# Altium schematic sheet 1_MICROCONTROLADOR.SchDoc
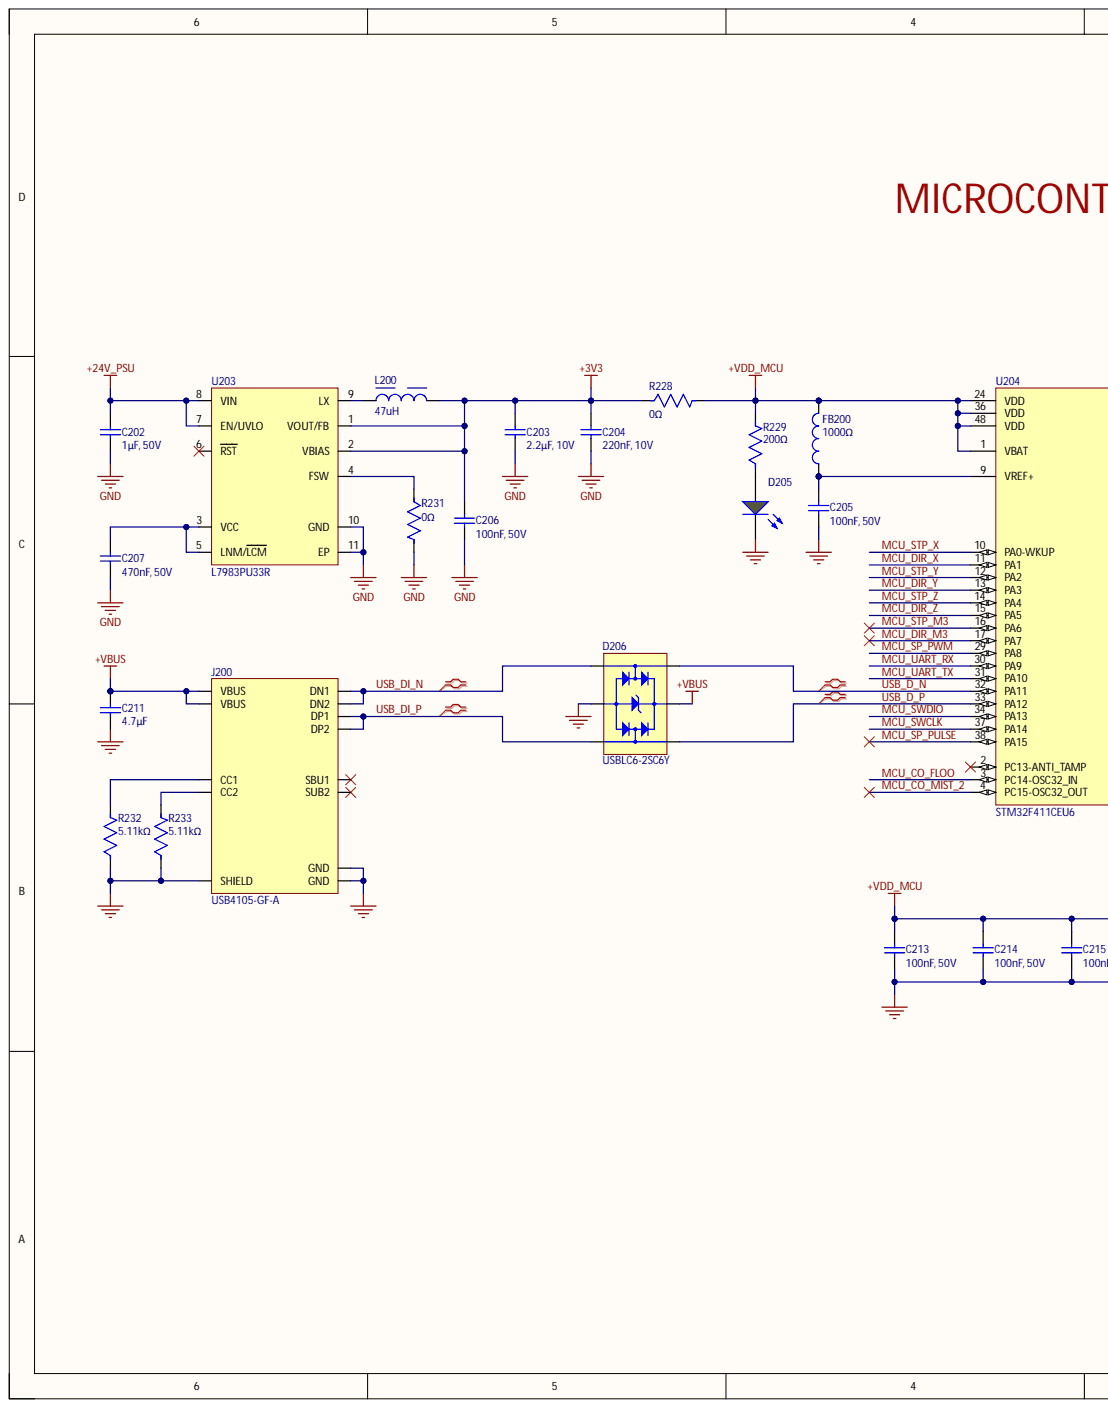
[diagram p0: 1_MICROCONTROLADOR.SchDoc, left]
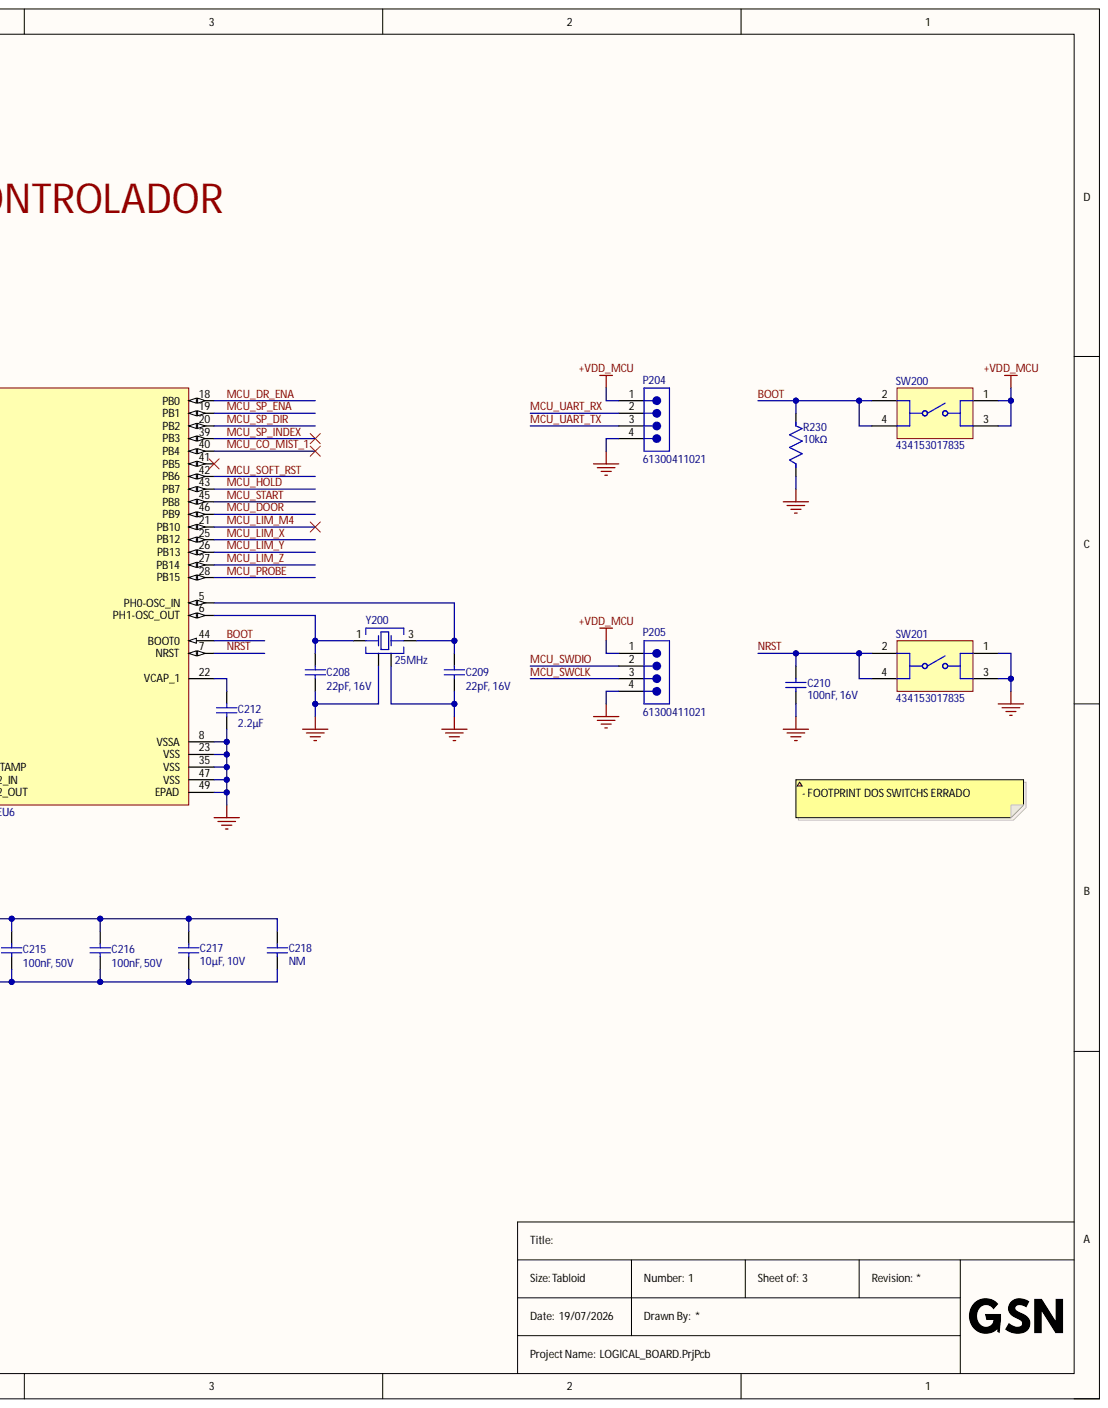
[diagram p1: 1_MICROCONTROLADOR.SchDoc, right]

## Parts
C202  1μF, 50V  Rated Voltage (DC): 50V  [WCAP-CSGP_0805_L2W1.25H1.25]
C203  2.2μF, 10V  [WCAP-CSGP_0603_L1.6W0.8H0.8_Wave]
C204  220nF, 10V  Rated Voltage (DC): 10V  [WCAP-CSGP_0603_L1.6W0.8H0.8_Wave]
C205  100nF, 50V  [WCAP-CSGP_0603_L1.6W0.8H0.8_Wave]
C206  100nF, 50V  Rated Voltage (DC): 50V  [WCAP-CSGP_0603_L1.6W0.8H0.8_Wave]
C207  470nF, 50V  [WCAP-CSGP_0805_L2W1.25H1.25]
C208  22pF, 16V  Rated Voltage (DC): 16V  [WCAP-CSGP_0603_L1.6W0.8H0.8_Wave]
C209  22pF, 16V  Rated Voltage (DC): 16V  [WCAP-CSGP_0603_L1.6W0.8H0.8_Wave]
C210  100nF, 16V  [WCAP-CSGP_0603_L1.6W0.8H0.8_Wave]
C211  4.7μF  [WCAP-CSGP_0805_L2W1.25H1.25]
C212  2.2μF  [WCAP-CSGP_0603_L1.6W0.8H0.8_Wave]
C213  100nF, 50V  [WCAP-CSGP_0603_L1.6W0.8H0.8_Wave]
C214  100nF, 50V  Rated Voltage (DC): 50V  [WCAP-CSGP_0603_L1.6W0.8H0.8_Wave]
C215  100nF, 50V  Rated Voltage (DC): 50V  [WCAP-CSGP_0603_L1.6W0.8H0.8_Wave]
C216  100nF, 50V  Rated Voltage (DC): 50V  [WCAP-CSGP_0603_L1.6W0.8H0.8_Wave]
C217  10μF, 10V  Rated Voltage (DC): 10V  [WCAP-CSGP_0805_L2W1.25H1.25]
C218  NM  [WCAP-CSGP_0805_L2W1.25H1.25]
D205  Bright Green, 2V, 140deg  [WL-SMCW_0603_150060xx75000]  (value not drawn)
D206  USBLC6-2SC6Y  [SOT-23-6]
FB200  1000Ω  [WE-CBF_0603_L1.6W0.8H0.8_HS_WB]
J200  USB4105-GF-A  [USB4105-GF-A]
L200  47uH  [WE-MAIA_3015]
P204  61300411021  [61300411021]
P205  61300411021  [61300411021]
R228  0Ω  [0805-WAVE]
R229  200Ω  [0603-WAVE]
R230  10kΩ  [0603-WAVE]
R231  0Ω  [0603-WAVE]
R232  5.11kΩ  [0603-WAVE]
R233  5.11kΩ  [0603-WAVE]
SW200  434153017835  [434153017835]
SW201  434153017835  [434153017835]
U203  L7983PU33R  [10-WFDFN-EP]
U204  STM32F411CEU6  [UFQFN-48-7X7_EXPOSED_PAD]
Y200  25MHz  [WE-XTAL_CFPX-180]

## Nets
+3V3: C203.2 C204.2 C206.2 L200.2 R228.1 U203.1(VOUT/FB) U203.2(VBIAS)
+24V_PSU: C202.2 U203.7(EN/UVLO) U203.8(VIN)
+VBUS: C211.2 D206.5 J200.A4_B9(VBUS) J200.B4_A9(VBUS)
+VDD_MCU: C213.2 C214.2 C215.2 C216.2 C217.2 C218.2 FB200.2 P204.1 P205.1 R228.2 R229.2 SW200.1 SW200.3 U204.1(VBAT) U204.24(VDD) U204.36(VDD) U204.48(VDD)
GND: C202.1 C203.1 C204.1 C205.1 C206.1 C207.1 C208.1 C209.1 C210.1 C211.1 C212.1 C213.1 C214.1 C215.1 C216.1 C217.1 C218.1 D205.1 D206.2 J200.A1_B12(GND) J200.B1_A12(GND) J200.SH(SHIELD) P204.4 P205.4 R230.1 R231.2 R232.1 R233.1 SW201.1 SW201.3 U203.10(GND) U203.11(EP) U204.8(VSSA) U204.23(VSS) U204.35(VSS) U204.47(VSS) U204.49(EPAD) Y200.2 Y200.4
BOOT: R230.2 SW200.2 SW200.4 U204.44(BOOT0)
MCU_CO_FLOO: U204.3(PC14-OSC32_IN)
MCU_CO_MIST_1: U204.40(PB4)
MCU_CO_MIST_2: U204.4(PC15-OSC32_OUT)
MCU_DIR_M3: U204.17(PA7)
MCU_DIR_X: U204.11(PA1)
MCU_DIR_Y: U204.13(PA3)
MCU_DIR_Z: U204.15(PA5)
MCU_DOOR: U204.46(PB9)
MCU_DR_ENA: U204.18(PB0)
MCU_HOLD: U204.43(PB7)
MCU_LIM_M4: U204.21(PB10)
MCU_LIM_X: U204.25(PB12)
MCU_LIM_Y: U204.26(PB13)
MCU_LIM_Z: U204.27(PB14)
MCU_PROBE: U204.28(PB15)
MCU_SOFT_RST: U204.42(PB6)
MCU_SP_DIR: U204.20(PB2)
MCU_SP_ENA: U204.19(PB1)
MCU_SP_INDEX: U204.39(PB3)
MCU_SP_PULSE: U204.38(PA15)
MCU_SP_PWM: U204.29(PA8)
MCU_START: U204.45(PB8)
MCU_STP_M3: U204.16(PA6)
MCU_STP_X: U204.10(PA0-WKUP)
MCU_STP_Y: U204.12(PA2)
MCU_STP_Z: U204.14(PA4)
MCU_SWCLK: P205.3 U204.37(PA14)
MCU_SWDIO: P205.2 U204.34(PA13)
MCU_UART_RX: P204.2 U204.30(PA9)
MCU_UART_TX: P204.3 U204.31(PA10)
NRST: C210.2 SW201.2 SW201.4 U204.7(NRST)
USB_D_N: D206.6 U204.32(PA11)
USB_D_P: D206.4 U204.33(PA12)
USB_DI_N: D206.1 J200.A7(DN1) J200.B7(DN2)
USB_DI_P: D206.3 J200.A6(DP1) J200.B6(DP2)
NetC205_2: C205.2 FB200.1 U204.9(VREF+)
NetC207_2: C207.2 U203.3(VCC) U203.5(LNM/LCM)
NetC208_2: C208.2 U204.6(PH1-OSC_OUT) Y200.1
NetC209_2: C209.2 U204.5(PH0-OSC_IN) Y200.3
NetC212_2: C212.2 U204.22(VCAP_1)
NetD205_2: D205.2 R229.1
NetJ200_A5: J200.A5(CC1) R232.2
NetJ200_B5: J200.B5(CC2) R233.2
NetL200_1: L200.1 U203.9(LX)
NetR231_1: R231.1 U203.4(FSW)

Not connected: J200 A8(SBU1) B8(SUB2); U203 6(RST); U204 2(PC13-ANTI_TAMP) 41(PB5)

## Library data of the parts
C202 = 885012207103R: WCAP-CSGP Multilayer Ceramic Chip Capacitor, General Purpose, size 0805, 1μF, 50V; Manufacturer: Wurth Elektronik; Capacitance: 1uF; Capacitance Tolerance: +/-10%; Case/Size Code: 0805; Ceramic Type: X7R; Database Table Name: Capacitors; Dissipation Factor: 10%; Insulation Resistance: 0.1Gohm; Technical Reference: X7R0805105K050DFCT20000; Category: Multilayer Ceramic Chip Capacitors; Component Kind: Standard; ComponentLink1Description: Website Link; ComponentLink1URL: https://www.we-online.com/redexpert/article/885012207103R?ad; ComponentLink2Description: Datasheet Link; ComponentLink2URL: https://www.we-online.com/redexpert/spec/885012207103R?ad; Height: 1.25mm; Length: 2mm; Library Name: WE - Passive Components.DbLib; Manufacturer Part Number: 885012207103R; Match Code: WCAP-CSGP; Mount: Surface Mount; Operating Temperature Max: 125degC; Operating Temperature Min: -55degC; Packaging: Tape and Reel; Simulation: 0805_885012207103R_1uF; Width: 1.25mm
C203 = 885012206027: WCAP-CSGP Multilayer Ceramic Chip Capacitor, General Purpose, size 0603, 2.2μF, 10V; Manufacturer: Wurth Elektronik; Capacitance: 2.2uF; Capacitance Tolerance: +/-10%; Case/Size Code: 0603; Ceramic Type: X7R; Database Table Name: Capacitors; Dissipation Factor: 10%; Insulation Resistance: 0.02Gohm; Technical Reference: X7R0603225K010DFCT10000; Category: Multilayer Ceramic Chip Capacitors; Component Kind: Standard; ComponentLink1Description: Website Link; ComponentLink1URL: https://www.we-online.com/redexpert/article/885012206027?ad; ComponentLink2Description: Datasheet Link; ComponentLink2URL: https://www.we-online.com/redexpert/spec/885012206027?ad; Height: 0.8mm; Length: 1.6mm; Library Name: WE - Passive Components.DbLib; Manufacturer Part Number: 885012206027; Match Code: WCAP-CSGP; Mount: Surface Mount; Operating Temperature Max: 125degC; Operating Temperature Min: -55degC; Packaging: Tape and Reel; Rated Voltage (DC): 10V; Simulation: 0603_885012206027_2.2uF; Width: 0.8mm
C204 = 885012206022: WCAP-CSGP Multilayer Ceramic Chip Capacitor, General Purpose, size 0603, 220nF, 10V; Manufacturer: Wurth Elektronik; Capacitance Tolerance: +/-10%; Case/Size Code: 0603; Ceramic Type: X7R; Database Table Name: Capacitors; Dissipation Factor: 5%; Insulation Resistance: 2.3Gohm; Technical Reference: X7R0603224K010DFCT10000; Capacitance: 220nF; Category: Multilayer Ceramic Chip Capacitors; Component Kind: Standard; ComponentLink1Description: Website Link; ComponentLink1URL: https://www.we-online.com/redexpert/article/885012206022?ad; ComponentLink2Description: Datasheet Link; ComponentLink2URL: https://www.we-online.com/redexpert/spec/885012206022?ad; Height: 0.8mm; Length: 1.6mm; Library Name: WE - Passive Components.DbLib; Manufacturer Part Number: 885012206022; Match Code: WCAP-CSGP; Mount: Surface Mount; Operating Temperature Max: 125degC; Operating Temperature Min: -55degC; Packaging: Tape and Reel; Simulation: 0603_885012206022_220nF; Width: 0.8mm
C205 C206 C213-C216 = 885012206095: WCAP-CSGP Multilayer Ceramic Chip Capacitor, General Purpose, size 0603, 100nF, 50V; Manufacturer: Wurth Elektronik; Capacitance Tolerance: +/-10%; Case/Size Code: 0603; Ceramic Type: X7R; Database Table Name: Capacitors; Dissipation Factor: 3%; Insulation Resistance: 5Gohm; Technical Reference: X7R0603104K050DFCT10000; Capacitance: 100nF; Category: Multilayer Ceramic Chip Capacitors; Component Kind: Standard; ComponentLink1Description: Website Link; ComponentLink1URL: https://www.we-online.com/redexpert/article/885012206095?ad; ComponentLink2Description: Datasheet Link; ComponentLink2URL: https://www.we-online.com/redexpert/spec/885012206095?ad; Height: 0.8mm; Length: 1.6mm; Library Name: WE - Passive Components.DbLib; Manufacturer Part Number: 885012206095; Match Code: WCAP-CSGP; Mount: Surface Mount; Operating Temperature Max: 125degC; Operating Temperature Min: -55degC; Packaging: Tape and Reel; Simulation: 0603_885012206095_100nF; Width: 0.8mm
  C205 C213: Rated Voltage (DC): 50V
C207 = 885012207102: WCAP-CSGP Multilayer Ceramic Chip Capacitor, General Purpose, size 0805, 470nF, 50V; Manufacturer: Wurth Elektronik; Capacitance Tolerance: +/-10%; Case/Size Code: 0805; Ceramic Type: X7R; Database Table Name: Capacitors; Dissipation Factor: 3%; Insulation Resistance: 1.1Gohm; Technical Reference: X7R0805474K050DFCT10000; Capacitance: 470nF; Category: Multilayer Ceramic Chip Capacitors; Component Kind: Standard; ComponentLink1Description: Website Link; ComponentLink1URL: https://www.we-online.com/redexpert/article/885012207102?ad; ComponentLink2Description: Datasheet Link; ComponentLink2URL: https://www.we-online.com/redexpert/spec/885012207102?ad; Height: 1.25mm; Length: 2mm; Library Name: WE - Passive Components.DbLib; Manufacturer Part Number: 885012207102; Match Code: WCAP-CSGP; Mount: Surface Mount; Operating Temperature Max: 125degC; Operating Temperature Min: -55degC; Packaging: Tape and Reel; Rated Voltage (DC): 50V; Simulation: 0805_885012207102_470nF; Width: 1.25mm
C208 C209 = 885012006019: WCAP-CSGP Multilayer Ceramic Chip Capacitor, General Purpose, size 0603, 22pF, 16V; Manufacturer: Wurth Elektronik; Capacitance Tolerance: +/-5%; Case/Size Code: 0603; Ceramic Type: NP0; Database Table Name: Capacitors; Insulation Resistance: 10Gohm; Q Factor: 840; Technical Reference: NP00603220J016DFCT10000; Capacitance: 22pF; Category: Multilayer Ceramic Chip Capacitors; Component Kind: Standard; ComponentLink1Description: Website Link; ComponentLink1URL: https://www.we-online.com/redexpert/article/885012006019?ad; ComponentLink2Description: Datasheet Link; ComponentLink2URL: https://www.we-online.com/redexpert/spec/885012006019?ad; Height: 0.8mm; Length: 1.6mm; Library Name: WE - Passive Components.DbLib; Manufacturer Part Number: 885012006019; Match Code: WCAP-CSGP; Mount: Surface Mount; Operating Temperature Max: 125degC; Operating Temperature Min: -55degC; Packaging: Tape and Reel; Simulation: 0603_885012006019_22pF; Width: 0.8mm
C210 = 885012206046: WCAP-CSGP Multilayer Ceramic Chip Capacitor, General Purpose, size 0603, 100nF, 16V; Manufacturer: Wurth Elektronik; Capacitance Tolerance: +/-10%; Case/Size Code: 0603; Ceramic Type: X7R; Database Table Name: Capacitors; Dissipation Factor: 3.5%; Insulation Resistance: 5Gohm; Technical Reference: X7R0603104K016DFCT10000; Capacitance: 100nF; Category: Multilayer Ceramic Chip Capacitors; Component Kind: Standard; ComponentLink1Description: Website Link; ComponentLink1URL: https://www.we-online.com/redexpert/article/885012206046?ad; ComponentLink2Description: Datasheet Link; ComponentLink2URL: https://www.we-online.com/redexpert/spec/885012206046?ad; Height: 0.8mm; Length: 1.6mm; Library Name: WE - Passive Components.DbLib; Manufacturer Part Number: 885012206046; Match Code: WCAP-CSGP; Mount: Surface Mount; Operating Temperature Max: 125degC; Operating Temperature Min: -55degC; Packaging: Tape and Reel; Rated Voltage (DC): 16V; Simulation: 0603_885012206046_100nF; Width: 0.8mm
C211 = 885012207053: WCAP-CSGP Multilayer Ceramic Chip Capacitor, General Purpose, size 0805, 4.7μF, 16V; Manufacturer: Wurth Elektronik; Capacitance: 4.7uF; Capacitance Tolerance: +/-10%; Case/Size Code: 0805; Ceramic Type: X7R; Database Table Name: Capacitors; Dissipation Factor: 10%; Insulation Resistance: 0.02Gohm; Technical Reference: X7R0805475K016DFCT10000; Category: Multilayer Ceramic Chip Capacitors; Component Kind: Standard; ComponentLink1Description: Website Link; ComponentLink1URL: https://www.we-online.com/redexpert/article/885012207053?ad; ComponentLink2Description: Datasheet Link; ComponentLink2URL: https://www.we-online.com/redexpert/spec/885012207053?ad; Height: 1.25mm; Length: 2mm; Library Name: WE - Passive Components.DbLib; Manufacturer Part Number: 885012207053; Match Code: WCAP-CSGP; Mount: Surface Mount; Operating Temperature Max: 125degC; Operating Temperature Min: -55degC; Packaging: Tape and Reel; Rated Voltage (DC): 16V; Simulation: 0805_885012207053_4.7uF; Width: 1.25mm
C212 = 885012106004: WCAP-CSGP Multilayer Ceramic Chip Capacitor, General Purpose, size 0603, 2.2μF, 6.3V; Manufacturer: Wurth Elektronik; Capacitance: 2.2uF; Capacitance Tolerance: +/-20%; Case/Size Code: 0603; Ceramic Type: X5R; Database Table Name: Capacitors; Dissipation Factor: 10%; Insulation Resistance: 0.05Gohm; Technical Reference: X5R0603225M6R3DFCT10000; Category: Multilayer Ceramic Chip Capacitors; Component Kind: Standard; ComponentLink1Description: Website Link; ComponentLink1URL: https://www.we-online.com/redexpert/article/885012106004?ad; ComponentLink2Description: Datasheet Link; ComponentLink2URL: https://www.we-online.com/redexpert/spec/885012106004?ad; Height: 0.8mm; Length: 1.6mm; Library Name: WE - Passive Components.DbLib; Manufacturer Part Number: 885012106004; Match Code: WCAP-CSGP; Mount: Surface Mount; Operating Temperature Max: 85degC; Operating Temperature Min: -55degC; Packaging: Tape and Reel; Rated Voltage (DC): 6.3V; Simulation: 0603_885012106004_2.2uF; Width: 0.8mm
C217 C218 = 885012207026: WCAP-CSGP Multilayer Ceramic Chip Capacitor, General Purpose, size 0805, 10μF, 10V; Manufacturer: Wurth Elektronik; Capacitance: 10uF; Capacitance Tolerance: +/-10%; Case/Size Code: 0805; Ceramic Type: X7R; Database Table Name: Capacitors; Dissipation Factor: 10%; Insulation Resistance: 0.01Gohm; Technical Reference: X7R0805106K010DFCT10000; Category: Multilayer Ceramic Chip Capacitors; Component Kind: Standard; ComponentLink1Description: Website Link; ComponentLink1URL: https://www.we-online.com/redexpert/article/885012207026?ad; ComponentLink2Description: Datasheet Link; ComponentLink2URL: https://www.we-online.com/redexpert/spec/885012207026?ad; Height: 1.25mm; Length: 2mm; Library Name: WE - Passive Components.DbLib; Manufacturer Part Number: 885012207026; Match Code: WCAP-CSGP; Mount: Surface Mount; Operating Temperature Max: 125degC; Operating Temperature Min: -55degC; Packaging: Tape and Reel; Simulation: 0805_885012207026_10uF; Width: 1.25mm
  C218: Rated Voltage (DC): 10V
D205 = 150060VS75000: WL-SMCW Mono-color Chip LED Waterclear, SMT, size 0603, Bright Green, 2V, 140°; Manufacturer: Wurth Elektronik; Case/Size Code: 0603; Chip Technology: AlInGaP; Database Table Name: LEDs; Dominant Wavelength: 570nm; Luminous Intensity: 40mcd; Peak Wavelength: 572nm; Category: Signal LEDs; Component Kind: Standard; ComponentLink1Description: Website Link; ComponentLink1URL: https://www.we-online.com/redexpert/article/150060VS75000?ad; ComponentLink2Description: Datasheet Link; ComponentLink2URL: https://www.we-online.com/redexpert/spec/150060VS75000?ad; Emitting Color: Bright Green; Forward Voltage: 2V; Height: 0.7mm; Length: 1.6mm; Library Name: WE - Optoelectronic Components.DbLib; Manufacturer Part Number: 150060VS75000; Match Code: WL-SMCW; Mount: Surface Mount; Operating Temperature Max: 85degC; Operating Temperature Min: -40degC; Packaging: Tape and Reel; Simulation: 0603_150060VS75000; Viewing Angle: 140deg; Width: 0.8mm
D206 = USBLC6-2SC6Y: TVS DIODE 5.25VWM 17VC SOT23-6; Manufacturer: STMicroelectronics; Breakdown Voltage: 6V; Clamping Voltage: 17V; Direction: Unidirectional; Element Configuration: Dual; ESD Protection: Yes; ESR: 330Ω; Forward Voltage: 860mV; Leakage Current: 150nA; Max Junction Temperature (Tj): 150°C; Number of Bidirectional Channels: 2; Number of Elements: 1; Operating Supply Voltage: 1.1V; Peak Pulse Current: 5A; Reverse Standoff Voltage: 5.25V; Test Current: 1mA; Value: 2.5pF; Case/Package: SOT-23-6; Category: TVS Diodes; China RoHS: Compliant; Component Kind: Standard; ComponentLink1Description: https://datasheet.octopart.com:443/USBLC6-2SC6Y-STMicroelectronics-datasheet-12533815.pdf?src-supplier=ODG+(Origin+Data+Global); ComponentLink1URL: https://datasheet.octopart.com:443/USBLC6-2SC6Y-STMicroelectronics-datasheet-12533815.pdf?src-supplier=ODG+(Origin+Data+Global); ComponentLink2Description: https://datasheet.octopart.com:443/USBLC6-2SC6Y-STMicroelectronics-datasheet-13733956.pdf?src-supplier=STMicroelectronics; ComponentLink2URL: https://datasheet.octopart.com:443/USBLC6-2SC6Y-STMicroelectronics-datasheet-13733956.pdf?src-supplier=STMicroelectronics; ComponentLink3Description: https://datasheet.octopart.com:443/USBLC6-2SC6Y-STMicroelectronics-datasheet-10412581.pdf?src-supplier=Factory+Futures; ComponentLink3URL: https://datasheet.octopart.com:443/USBLC6-2SC6Y-STMicroelectronics-datasheet-10412581.pdf?src-supplier=Factory+Futures; ComponentLink4Description: https://datasheet.octopart.com:443/USBLC6-2SC6Y-STMicroelectronics-datasheet-525477.pdf?src-supplier=Factory+Futures; ComponentLink4URL: https://datasheet.octopart.com:443/USBLC6-2SC6Y-STMicroelectronics-datasheet-525477.pdf?src-supplier=Factory+Futures; Depth: 1.75mm; Height: 1.45mm; Lead Free: Lead Free; Length: 3mm; Library Name: TVS-Diodes.SchLib; Lifecycle Status: Production; Manufacturer Part Number: USBLC6-2SC6Y; Max Operating Temperature: 150°C; Max Reverse Leakage Current: 150nA; Min Breakdown Voltage: 6V; Min Operating Temperature: -40°C; Mounting Technology: Surface Mount; Number of Channels: 2; Number of Terminals: 6; Packaging: Tape and Reel; Peak Reverse Current: 150nA; Pins: 6; Polarity: Unidirectional; Power Line Protection: Yes; Pricing: 1=0.46, 10=0.298, 100=0.211, 500=0.164, 1000=0.144 (USD); Radiation Hardening: No; REACH SVHC: Yes; RoHS: Compliant; Schedule B: 8536300000, 8536300000|8536300000|8536300000|8536300000|8536300000; Stock: 64605; Supplier: DigiKey; Supplier Part Number: 497-11882-1-ND; Termination: SMD/SMT; Width: 1.75mm
FB200 = 74279266: WE-CBF SMT EMI Suppression Ferrite Bead, size 0603, 1000Ω, 0.65A; Manufacturer: Wurth Elektronik; Case/Size Code: 0603; Database Table Name: EMC & RFI Components; DC Resistance Max: 0.6Ω; Impedance Max: 1350Ω; Impedance Max Test Condition: 140MHz; Type: Wide Band; Category: Ferrites for PCB Assembly; Component Kind: Standard; ComponentLink1Description: Website Link; ComponentLink1URL: https://www.we-online.com/redexpert/article/74279266?ad; ComponentLink2Description: Datasheet Link; ComponentLink2URL: https://www.we-online.com/redexpert/spec/74279266?ad; Height: 0.8mm; Impedance @100MHz: 1000Ω; Length: 1.6mm; Library Name: WE - Passive Components.DbLib; Manufacturer Part Number: 74279266; Match Code: WE-CBF; Mount: Surface Mount; Operating Temperature Max: 125degC; Operating Temperature Min: -55degC; Packaging: Tape and Reel; Rated Current: 0.65A; Simulation: 0603_74279266_1000ohm; Width: 0.8mm
J200 = USB4105-GF-A: CONN RCP USB2.0 TYP C 16P SMD RA; Manufacturer: Global Connector Technology; Contact Resistance: 50mΩ; Current Rating: 6.25A; Dielectric Material: Liquid Crystal Polymer; Gender: Receptacle; Insulation Resistance: 100MΩ; Number of Contacts: 16; Number of Ports: 1; Voltage Rating: 20V; Category: USB Connectors; China RoHS: Compliant; Component Kind: Standard; ComponentLink1Description: https://datasheet.octopart.com:443/USB4105-GF-A-GCT-datasheet-166929323.pdf?src-supplier=SOS+electronic; ComponentLink1URL: https://datasheet.octopart.com:443/USB4105-GF-A-GCT-datasheet-166929323.pdf?src-supplier=SOS+electronic; ComponentLink2Description: https://datasheet.octopart.com:443/USB4105-GF-A-GCT-datasheet-188935996.pdf?src-supplier=TME; ComponentLink2URL: https://datasheet.octopart.com:443/USB4105-GF-A-GCT-datasheet-188935996.pdf?src-supplier=TME; ComponentLink3Description: https://datasheet.octopart.com:443/USB4105-GF-A-GCT-datasheet-188869076.pdf?src-supplier=TME; ComponentLink3URL: https://datasheet.octopart.com:443/USB4105-GF-A-GCT-datasheet-188869076.pdf?src-supplier=TME; ComponentLink4Description: https://datasheet.octopart.com:443/USB4105-GF-A-GCT-datasheet-166927665.pdf?src-supplier=TME; ComponentLink4URL: https://datasheet.octopart.com:443/USB4105-GF-A-GCT-datasheet-166927665.pdf?src-supplier=TME; Contact Gender: Female; Contact Material: Gold, Nickel; Height: 3.46mm; Library Name: Connectors.SchLib; Lifecycle Status: Production; Manufacturer Part Number: USB4105-GF-A; Max Operating Temperature: 85°C; Min Operating Temperature: -40°C; Number of Rows: 1; Orientation: Horizontal; Pins: 24; Pricing: 1=0.78, 10=0.658, 25=0.6172, 50=0.5876, 100=0.5595, 250=0.5244 (USD); REACH SVHC: Yes; RoHS: Compliant; Shell Finish: Nickel; Shell Material: Stainless Steel; Stock: 6325; Supplier: DigiKey; Supplier Part Number: 2073-USB4105-GF-ACT-ND; Terminal Pitch: 500µm; USB Standard: USB 2.0
L200 = 78438335470: WE-MAIA SMT Power Inductor, size 3015, 47uH, 0.5A, 2300mohm; Manufacturer: Wurth Elektronik; Case/Size Code: 3015; Database Table Name: Inductors; Saturation Current @ 10%: 0.75A; Saturation Current @ 30%: 1.5A; Self Resonant Frequency: 8MHz; Automotive: Yes; Category: Power Inductors; Component Kind: Standard; ComponentLink1Description: Website Link; ComponentLink1URL: https://www.we-online.com/redexpert/article/78438335470?ad; ComponentLink2Description: Datasheet Link; ComponentLink2URL: https://www.we-online.com/redexpert/spec/78438335470?ad; DC Resistance Max: 2300mohm; Height: 1.5mm; Inductance: 47uH; Length: 3mm; Library Name: WE - Passive Components.DbLib; Manufacturer Part Number: 78438335470; Match Code: WE-MAIA; Mount: Surface Mount; Operating Temperature Max: 125degC; Operating Temperature Min: -40degC; Packaging: Tape and Reel; Rated Current: 0.5A; Simulation: 3015_78438335470_47u; Width: 3mm
P204 P205 = 61300411021: WR-PHD Pin Header, THT, Angled, pitch 2.54mm, 1 Row, 4P; Manufacturer: Wurth Elektronik; Contact Resistance: 20mOhm; Database Table Name: Connectors; Rated Current: 3A; Withstanding Voltage: 500V; Working Voltage: 250V; Category: Board-to-Board Connectors; Component Kind: Standard; ComponentLink1Description: Website Link; ComponentLink1URL: https://www.we-online.com/redexpert/article/61300411021?ad; ComponentLink2Description: Datasheet Link; ComponentLink2URL: https://www.we-online.com/redexpert/spec/61300411021?ad; Gender: Pin Header; Lead Pitch: 2.54mm; Library Name: WE - Electromechanical Components.DbLib; Manufacturer Part Number: 61300411021; Match Code: WR-PHD; Mount: Through Hole; Number of Pins: 4; Number of Rows: 1; Operating Temperature Max: 105degC; Operating Temperature Min: -40degC; Orientation: Angled; Packaging: Bag
R228 = RC0805FR-070RL: RES 0 OHM 1% 1/8W 0805; Manufacturer: Yageo Group; Power Dissipation: 125mW; Temperature Coefficient: 100ppm/°C; Voltage Rating: 150V; Case/Package: 0805; Category: Chip SMD Resistors; China RoHS: Non-Compliant; Component Kind: Standard; ComponentLink1Description: https://datasheet.octopart.com:443/RC0805FR-070RL-Yageo-datasheet-127823281.pdf?src-supplier=Upverter; ComponentLink1URL: https://datasheet.octopart.com:443/RC0805FR-070RL-Yageo-datasheet-127823281.pdf?src-supplier=Upverter; ComponentLink2Description: https://datasheet.octopart.com:443/RC0805FR-070RL-Yageo-datasheet-17879891.pdf?src-supplier=IHS; ComponentLink2URL: https://datasheet.octopart.com:443/RC0805FR-070RL-Yageo-datasheet-17879891.pdf?src-supplier=IHS; ComponentLink3Description: https://datasheet.octopart.com:443/RC0805FR-070RL-Yageo-datasheet-8330313.pdf?src-supplier=Burklin+Elektronik; ComponentLink3URL: https://datasheet.octopart.com:443/RC0805FR-070RL-Yageo-datasheet-8330313.pdf?src-supplier=Burklin+Elektronik; ComponentLink4Description: https://datasheet.octopart.com:443/RC0805FR-070RL-Yageo-datasheet-164759695.pdf?src-supplier=Future+Electronics; ComponentLink4URL: https://datasheet.octopart.com:443/RC0805FR-070RL-Yageo-datasheet-164759695.pdf?src-supplier=Future+Electronics; ComponentLink5Description: https://datasheet.octopart.com:443/RC0805FR-070RL-Yageo-datasheet-12592811.pdf?src-supplier=Future+Electronics; ComponentLink5URL: https://datasheet.octopart.com:443/RC0805FR-070RL-Yageo-datasheet-12592811.pdf?src-supplier=Future+Electronics; ComponentLink6Description: https://datasheet.octopart.com:443/RC0805FR-070RL-Yageo-datasheet-8324252.pdf?src-supplier=Future+Electronics; ComponentLink6URL: https://datasheet.octopart.com:443/RC0805FR-070RL-Yageo-datasheet-8324252.pdf?src-supplier=Future+Electronics; ComponentLink7Description: https://datasheet.octopart.com:443/RC0805FR-070RL-Yageo-datasheet-141265859.pdf?src-supplier=TME; ComponentLink7URL: https://datasheet.octopart.com:443/RC0805FR-070RL-Yageo-datasheet-141265859.pdf?src-supplier=TME; ComponentLink8Description: https://datasheet.octopart.com/RC0805FR-070RL-Yageo-datasheet-73766026.pdf; ComponentLink8URL: https://datasheet.octopart.com/RC0805FR-070RL-Yageo-datasheet-73766026.pdf; ComponentLink9Description: http://sigma.octopart.com:80/conflict_mineral_statement/Yageo-company-3.pdf?src-supplier=Compliance+Statements; ComponentLink9URL: http://sigma.octopart.com:80/conflict_mineral_statement/Yageo-company-3.pdf?src-supplier=Compliance+Statements; ComponentLink10Description: http://sigma.octopart.com:80/rohs_statement/Yageo-company-2.pdf?src-supplier=Compliance+Statements; ComponentLink10URL: http://sigma.octopart.com:80/rohs_statement/Yageo-company-2.pdf?src-supplier=Compliance+Statements; Composition: Thick Film; Contact Plating: Tin; Depth: 1.25mm; Height: 500µm; Lead Free: Lead Free; Length: 2mm; Library Name: Resistors-0805-E96-Yageo.SchLib; Lifecycle Status: Production; Manufacturer Part Number: RC0805FR-070RL; Max Operating Temperature: 155°C; Military Standard: Not; Min Operating Temperature: -55°C; Mounting Technology: Surface Mount; Number of Terminals: 2; Power Rating: 125mW; Pricing: 1=0.1, 10=0.017, 25=0.0144, 50=0.0124, 100=0.0109, 250=0.00916, 500=0.00808, 1000=0.00715 (USD); Radiation Hardening: No; REACH SVHC: Yes; RoHS: Compliant; Stock: 748818; Supplier: DigiKey; Supplier Part Number: 311-0.0CRCT-ND; Tolerance: 1%; Value: 0Ω; Width: 1.25mm
R229 = RC0603FR-07200RL: RES 200 OHM 1% 1/10W 0603; Manufacturer: Yageo Group; Max Power Dissipation: 100mW; Temperature Coefficient: ±200ppm/°C; Voltage Rating: 75V; Voltage Rating (AC): 50V; Case Code (Imperial): 0603; Case Code (Metric): 1608; Case/Package: 0603; Category: Chip SMD Resistors; China RoHS: Non-Compliant; Component Kind: Standard; Component Link Description: https://www.yageogroup.com/content/datasheet/asset/file/PYU-RC_GROUP_51_ROHS_L; Component Link URL: https://www.yageogroup.com/content/datasheet/asset/file/PYU-RC_GROUP_51_ROHS_L; Composition: Thick Film; Contact Plating: Tin; Datasheet: https://www.yageogroup.com/content/datasheet/asset/file/PYU-RC_GROUP_51_ROHS_L; Depth: 800µm; Features: Moisture Resistant; Height: 450µm; Lead Free: Lead Free; Length: 1.6mm; Library Name: Resistors-0603-E96-Yageo.SchLib; Lifecycle Status: Production; Manufacturer Part Number: RC0603FR-07200RL; Max Operating Temperature: 155°C; Military Standard: Not; Min Operating Temperature: -55°C; Mounting Technology: Surface Mount; Number of Terminals: 2; Number of Terminations: 2; Packaging: Tape & Reel; Power Dissipation: 100mW; Power Rating: 100mW; Radiation Hardening: No; REACH SVHC: Yes; RoHS: Compliant; Supplier: DigiKey; Supplier Part Number: 311-200HRCT-ND; Termination: SMD/SMT; Tolerance: 1%; Value: 200Ω; Voltage Rating (DC): 50V; Weight: 2.012816mg; Width: 800µm; Working Voltage: 75V
R230 = RC0603FR-0710KL: RES 10K OHM 1% 1/10W 0603; Manufacturer: Yageo Group; Max Power Dissipation: 100mW; Temperature Coefficient: ±200ppm/°C; Voltage Rating: 75V; Voltage Rating (AC): 50V; Case Code (Imperial): 0603; Case Code (Metric): 1608; Case/Package: 0603; Category: Chip SMD Resistors; China RoHS: Non-Compliant; Component Kind: Standard; Component Link Description: https://www.yageogroup.com/content/datasheet/asset/file/PYU-RC_GROUP_51_ROHS_L; Component Link URL: https://www.yageogroup.com/content/datasheet/asset/file/PYU-RC_GROUP_51_ROHS_L; Composition: Thick Film; Contact Plating: Tin; Datasheet: https://www.yageogroup.com/content/datasheet/asset/file/PYU-RC_GROUP_51_ROHS_L; Depth: 800µm; Features: Moisture Resistant; Height: 450µm; Lead Free: Lead Free; Length: 1.6mm; Library Name: Resistors-0603-E96-Yageo.SchLib; Lifecycle Status: Production; Manufacturer Part Number: RC0603FR-0710KL; Max Operating Temperature: 155°C; Military Standard: Not; Min Operating Temperature: -55°C; Mounting Technology: Surface Mount; Number of Terminals: 2; Number of Terminations: 2; Packaging: Tape & Reel; Power Dissipation: 100mW; Power Rating: 100mW; Radiation Hardening: No; REACH SVHC: Yes; RoHS: Compliant; Supplier: DigiKey; Supplier Part Number: 311-10.0KHRCT-ND; Termination: SMD/SMT; Tolerance: 1%; Value: 10kΩ; Voltage Rating (DC): 50V; Weight: 2.012816mg; Width: 800µm; Working Voltage: 75V
R231 = RC0603FR-070RL: RES 0 OHM 1% 1/10W 0603; Manufacturer: Yageo Group; Max Power Dissipation: 100mW; Temperature Coefficient: ±200ppm/°C; Voltage Rating: 75V; Voltage Rating (AC): 50V; Case Code (Imperial): 0603; Case Code (Metric): 1608; Case/Package: 0603; Category: Chip SMD Resistors; China RoHS: Non-Compliant; Component Kind: Standard; Component Link Description: https://www.yageogroup.com/content/datasheet/asset/file/PYU-RC_GROUP_51_ROHS_L; Component Link URL: https://www.yageogroup.com/content/datasheet/asset/file/PYU-RC_GROUP_51_ROHS_L; Composition: Thick Film; Contact Plating: Tin; Datasheet: https://www.yageogroup.com/content/datasheet/asset/file/PYU-RC_GROUP_51_ROHS_L; Depth: 800µm; Features: Moisture Resistant; Height: 450µm; Lead Free: Lead Free; Length: 1.6mm; Library Name: Resistors-0603-E96-Yageo.SchLib; Lifecycle Status: Production; Manufacturer Part Number: RC0603FR-070RL; Max Operating Temperature: 155°C; Military Standard: Not; Min Operating Temperature: -55°C; Mounting Technology: Surface Mount; Number of Terminals: 2; Number of Terminations: 2; Packaging: Tape & Reel; Power Dissipation: 100mW; Power Rating: 100mW; Radiation Hardening: No; REACH SVHC: Yes; RoHS: Compliant; Supplier: DigiKey; Supplier Part Number: 311-0.0HRCT-ND; Termination: SMD/SMT; Tolerance: 1%; Value: 0Ω; Voltage Rating (DC): 50V; Weight: 2.012816mg; Width: 800µm; Working Voltage: 75V
R232 R233 = RC0603FR-075K11L: RES 5.11K OHM 1% 1/10W 0603; Manufacturer: Yageo Group; Max Power Dissipation: 100mW; Temperature Coefficient: ±200ppm/°C; Voltage Rating: 75V; Voltage Rating (AC): 50V; Case Code (Imperial): 0603; Case Code (Metric): 1608; Case/Package: 0603; Category: Chip SMD Resistors; China RoHS: Non-Compliant; Component Kind: Standard; Component Link Description: https://www.yageogroup.com/content/datasheet/asset/file/PYU-RC_GROUP_51_ROHS_L; Component Link URL: https://www.yageogroup.com/content/datasheet/asset/file/PYU-RC_GROUP_51_ROHS_L; Composition: Thick Film; Contact Plating: Tin; Datasheet: https://www.yageogroup.com/content/datasheet/asset/file/PYU-RC_GROUP_51_ROHS_L; Depth: 800µm; Features: Moisture Resistant; Height: 450µm; Lead Free: Lead Free; Length: 1.6mm; Library Name: Resistors-0603-E96-Yageo.SchLib; Lifecycle Status: Production; Manufacturer Part Number: RC0603FR-075K11L; Max Operating Temperature: 155°C; Military Standard: Not; Min Operating Temperature: -55°C; Mounting Technology: Surface Mount; Number of Terminals: 2; Number of Terminations: 2; Packaging: Tape & Reel; Power Dissipation: 100mW; Power Rating: 100mW; Radiation Hardening: No; REACH SVHC: Yes; RoHS: Compliant; Supplier: DigiKey; Supplier Part Number: 311-5.11KHRCT-ND; Termination: SMD/SMT; Tolerance: 1%; Value: 5.11kΩ; Voltage Rating (DC): 50V; Weight: 2.012816mg; Width: 800µm; Working Voltage: 75V
SW200 SW201 = 434153017835: WS-TASV J-Bend SMT Tact Switch, 3.5mm x 2.9mm x 1.7mm, SPST-NO, 350gf, 12V, 50mA; Manufacturer: Wurth Elektronik; Database Table Name: Switches; Washable: No; Category: Tact Switch; Circuit: SPST-NO; Component Kind: Standard; ComponentLink1Description: Website Link; ComponentLink1URL: https://www.we-online.com; ComponentLink2Description: Datasheet Link; ComponentLink2URL: https://www.we-online.com/components/products/datasheet/434153017835; Contact Material: Stainless Steel; Contact Plating: Silver; Height: 1.7mm; Length: 3.5mm; Library Name: WE - Electromechanical Components.DbLib; Manufacturer Part Number: 434153017835; Match Code: WS-TASV; Mount: Surface Mount; Operating Temperature Max: 85degC; Operating Temperature Min: -40degC; Operation Force: 350gf; Packaging: Tape and Reel; Rated Current: 50mA; Rated Voltage (DC): 12V; Terminal Material: Copper Alloy; Terminal Plating: Silver; Width: 2.9mm
U203 = L7983PU33R: IC REG BUCK 3.3V 300MA 10DFN; Manufacturer: STMicroelectronics; Max Output Voltage: 60V; Max Switching Frequency: 2.42MHz; Min Input Voltage: 3.5V; Min Output Voltage: 850mV; Nominal Supply Voltage: 24V; Number of Circuits: 1; Quiescent Current: 10µA; Synchronous Rectifier: Yes; Category: Voltage Regulators - Switching; China RoHS: Compliant; Component Kind: Standard; Country of Origin: Mainland China; Export Control Classification Number (ECCN) Code: EAR99; Harmonized Tariff Schedule (HTS) Code: 8542.39.00.60; Height - Seated (Max): 800µm; Introduction Date: 2020-10-06; Length: 3mm; Library Name: Voltage-Regulators.SchLib; Lifecycle Status: Production; Manufacturer Part Number: L7983PU33R; Max Operating Temperature: 150°C; Max Output current: 300mA; Max Supply Voltage: 60V; Min Operating Temperature: -40°C; Nominal Output Voltage: 3.3V; Number of Terminals: 10; Output Current: 300mA; Packaging: Tape and Reel; Pricing: 1=2.69, 10=2.001, 25=1.83, 100=1.6411, 250=1.55108, 500=1.5155 (USD); REACH SVHC: Yes; RoHS: Compliant; Schedule B: 8542390000, 8542390000|8542390000|8542390000|8542390000|8542390000|8542390000|8542390000|8542390000|8542390000|8542390000|8542390000|8542390000|8542390000|8542390000|8542390000|8542390000|8542390000|8542390000|8542390000|8542390000|8542390000|8542390000|8542390000|85; Stock: 4366; Supplier: DigiKey; Supplier Part Number: 497-L7983PU33RCT-ND; Terminal Pitch: 500µm; Topology: Buck; Width: 3mm
U204 = STM32F411CEU6: IC MCU 32BIT 512KB FLSH 48UFQFPN; Manufacturer: STMicroelectronics; ADC Channels (Resolution): 10-Ch 12-Bit; Clock Frequency: 26MHz; Core Architecture: ARM Cortex-M4; Data Bus Width: 32b; EEPROM Memory Size: 0B; Frequency: 100MHz; Interface: SDIO, USB; Max Power Dissipation: 625mW; Max Supply Current: 34.5mA; Max Supply Voltage: 3.6V; Memory Format: FLASH; Min Supply Voltage: 1.7V; Nominal Supply Current: 1.8µA; Number of A/D Converters: 1; Number of ADC Channels: 16; Number of Channels: 10; Number of I2C Channels: 3; Number of I/Os: 36; Number of SPI Channels: 5; Number of Timers/Counters: 2; Operating Supply Voltage: 3.3V; Oscillator Type: Internal; Peripherals: POR; RAM Size: 128kB; Watchdog Timer: Yes; Case/Package: UFQFPN; Category: Microcontrollers; China RoHS: Compliant; Component Kind: Standard; ComponentLink1Description: https://datasheet.octopart.com:443/STM32F411CEU6-STMicroelectronics-datasheet-41919523.pdf?src-supplier=element14+APAC; ComponentLink1URL: https://datasheet.octopart.com:443/STM32F411CEU6-STMicroelectronics-datasheet-41919523.pdf?src-supplier=element14+APAC; ComponentLink2Description: https://datasheet.octopart.com:443/STM32F411CEU6-STMicroelectronics-datasheet-27102711.pdf?src-supplier=Upverter; ComponentLink2URL: https://datasheet.octopart.com:443/STM32F411CEU6-STMicroelectronics-datasheet-27102711.pdf?src-supplier=Upverter; ComponentLink3Description: https://datasheet.octopart.com:443/STM32F411CEU6-STMicroelectronics-datasheet-114750309.pdf?src-supplier=Newark; ComponentLink3URL: https://datasheet.octopart.com:443/STM32F411CEU6-STMicroelectronics-datasheet-114750309.pdf?src-supplier=Newark; ComponentLink4Description: https://datasheet.octopart.com:443/STM32F411CEU6-STMicroelectronics-datasheet-34219326.pdf?src-supplier=Newark; ComponentLink4URL: https://datasheet.octopart.com:443/STM32F411CEU6-STMicroelectronics-datasheet-34219326.pdf?src-supplier=Newark; ComponentLink5Description: https://datasheet.octopart.com:443/STM32F411CEU6-STMicroelectronics-datasheet-82511007.pdf?src-supplier=SHENGYU+ELECTRONICS; ComponentLink5URL: https://datasheet.octopart.com:443/STM32F411CEU6-STMicroelectronics-datasheet-82511007.pdf?src-supplier=SHENGYU+ELECTRONICS; Flash Memory Size: 512kB; Height - Seated (Max): 600µm; Lead Free: Lead Free; Length: 7mm; Library Name: Microcontrollers.SchLib; Lifecycle Status: Production; Manufacturer Part Number: STM32F411CEU6; Max Operating Temperature: 85°C; Memory Size: 512kB; Min Operating Temperature: -40°C; Mounting Technology: Surface Mount; Number of Interrupts: 16; Number of Programmable I/O: 36; Number of Terminals: 48; Number of USART Channels: 3; Packaging: Cut Tape; Pins: 48; Pricing: 1=6.18, 10=4.745, 25=4.386, 100=3.991, 260=3.79569, 520=3.68344, 1040=3.59105, 2600=3.49353 (USD); Radiation Hardening: No; REACH SVHC: Yes; RoHS: Compliant; Schedule B: 8542310000, 8542310000|8542310000|8542310000|8542310000|8542310000|8542310000|8542310000|8542310000|8542310000|8542310000|8542310000|8542310000|8542310000|8542310000|8542310000|8542310000|8542310000|8542310000|8542310000|8542310000|8542310000|8542310000|8542310000|85; Stock: 3816; Supplier: DigiKey; Supplier Part Number: 497-14907-ND; Terminal Pitch: 500µm; Width: 7mm
Y200 = 830055474: WE-XTAL Quartz Crystal, CFPX-180, 25MHz, +/-50ppm; Manufacturer: Wurth Elektronik; Database Table Name: Crystals & Oscillators; Frequency Stability: 50ppm; Load Capacitance: 16pF; Application: Processor, USB, 3G/4G/5G, Ethernet, Small Cell, PHY, PCI Express; Case/Size Code: CFPX-180; Category: Crystals; Component Kind: Standard; ComponentLink1Description: Website Link; ComponentLink1URL: https://www.we-online.com/redexpert/article/830055474?ad; ComponentLink2Description: Datasheet Link; ComponentLink2URL: https://www.we-online.com/redexpert/spec/830055474?ad; Frequency: 25MHz; Frequency Tolerance: +/-50ppm; Height: 0.8mm; Length: 3.2mm; Library Name: WE - Passive Components.DbLib; Manufacturer Part Number: 830055474; Match Code: WE-XTAL; Mount: Surface Mount; Operating Temperature Max: 85degC; Operating Temperature Min: -40degC; Packaging: Tape and Reel; Width: 2.5mm
